# Altium schematic sheet Sensor-Sheet6.SchDoc(Sensor-Sheet6)
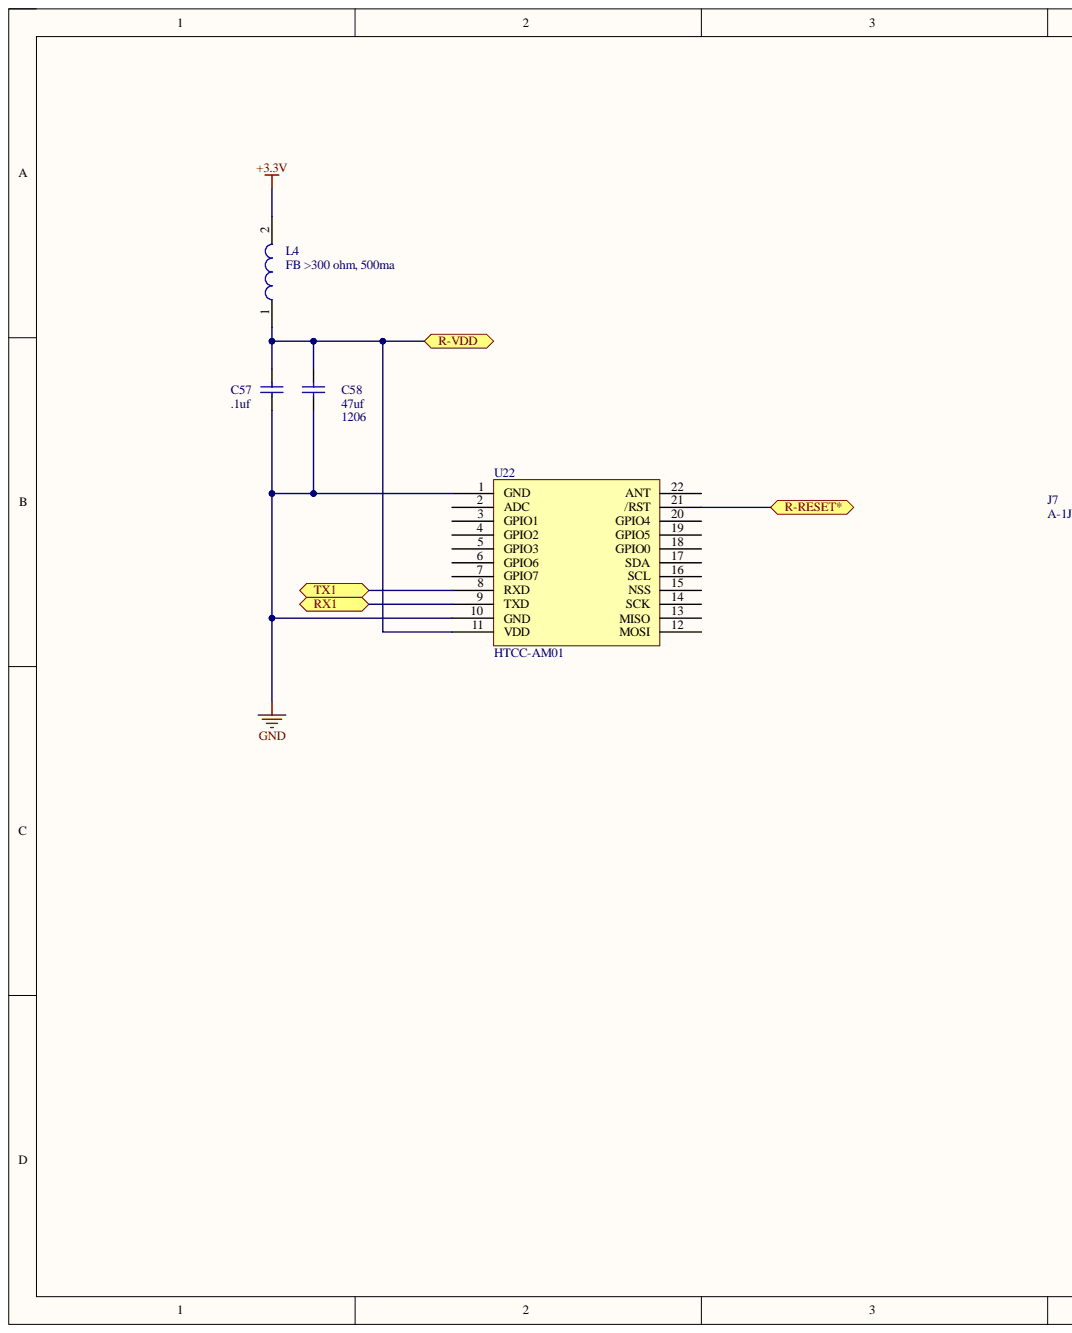
[diagram p0: Sensor-Sheet6.SchDoc, left]
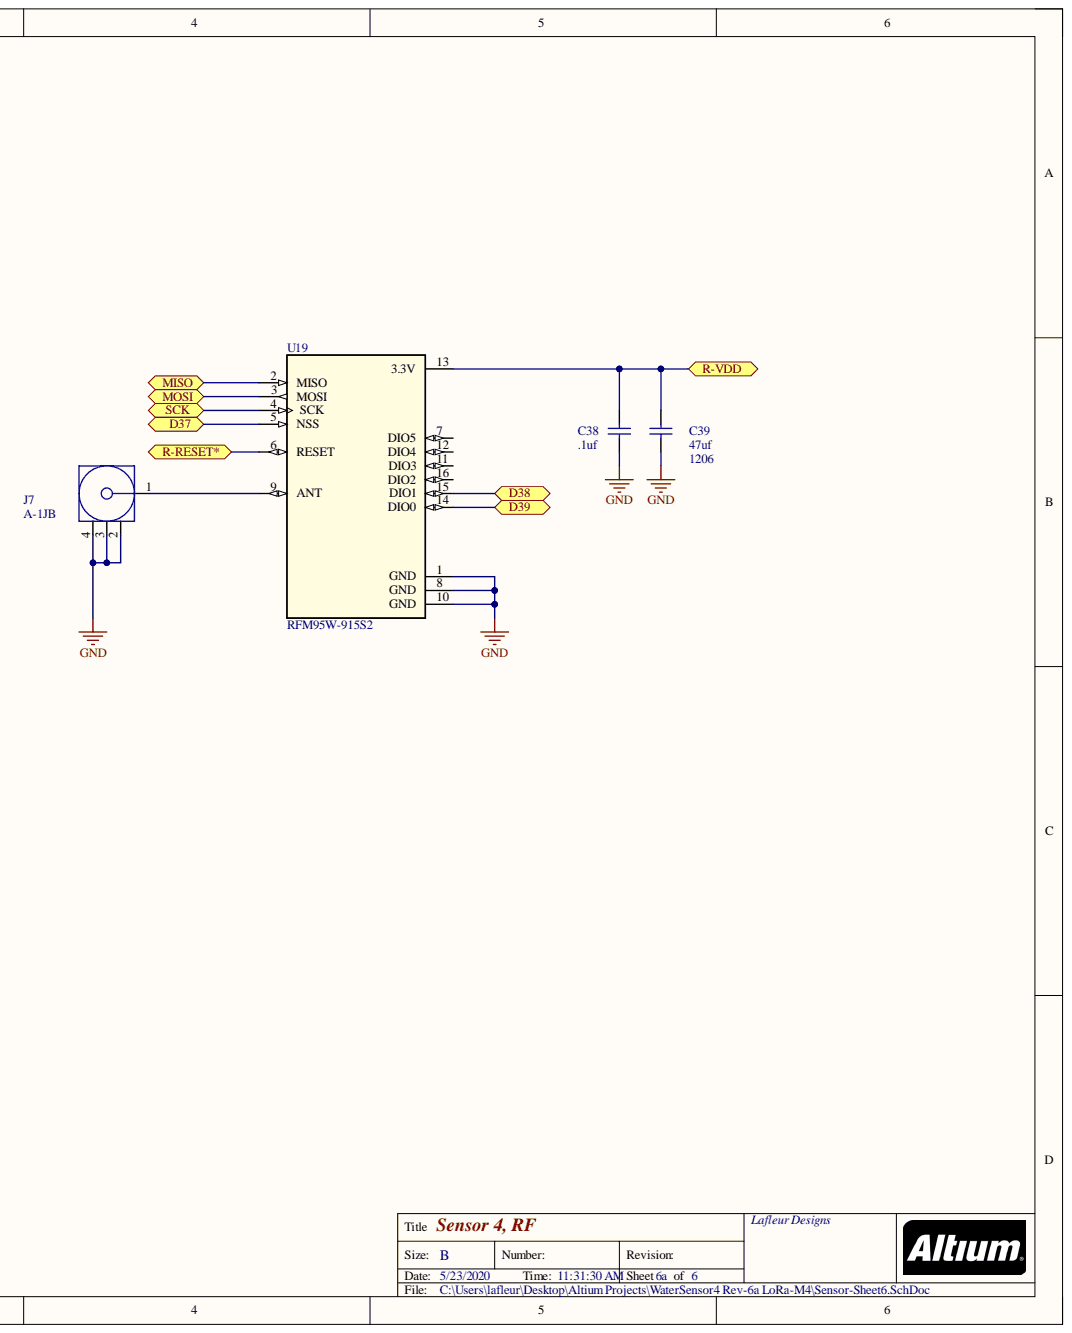
[diagram p1: Sensor-Sheet6.SchDoc, right]

## Parts
C38  .1uf  [c0805]
C39  47uf  [C1206]
C57  .1uf  [c0805]
C58  47uf  [C1206]
J7  A-1JB  [AMPH-A-1JB_V]
L4  FB >300 ohm, 500ma  [INDC2012X85N]
U19  RFM95W-915S2  [RFM95W]
U22  HTCC-AM01  [HTCC-AM01]

## Ports
D37, D38, D39, MISO, MOSI, R-RESET*, R-VDD, RX1, SCK, TX1

## Nets
+3.3V: L4.2
GND: C38.2 C39.2 C57.2 C58.2 J7.2 J7.3 J7.4 U19.1(GND) U19.8(GND) U19.10(GND) U22.1(GND) U22.10(GND)
D37: U19.5(NSS) ; port D37
D38: U19.15(DIO1) ; port D38
D39: U19.14(DIO0) ; port D39
MISO: U19.2(MISO) ; port MISO
MOSI: U19.3(MOSI) ; port MOSI
R-RESET*: U19.6(RESET) U22.21(/RST) ; port R-RESET*
R-VDD: C38.1 C39.1 C57.1 C58.1 L4.1 U19.13(3.3V) U22.11(VDD) ; port R-VDD
RX1: U22.9(TXD) ; port RX1
SCK: U19.4(SCK) ; port SCK
TX1: U22.8(RXD) ; port TX1
NetJ7_1: J7.1 U19.9(ANT)
NetU19_7: U19.7(DIO5)
NetU19_11: U19.11(DIO3)
NetU19_12: U19.12(DIO4)
NetU19_16: U19.16(DIO2)
NetU22_2: U22.2(ADC)
NetU22_3: U22.3(GPIO1)
NetU22_4: U22.4(GPIO2)
NetU22_5: U22.5(GPIO3)
NetU22_6: U22.6(GPIO6)
NetU22_7: U22.7(GPIO7)
NetU22_12: U22.12(MOSI)
NetU22_13: U22.13(MISO)
NetU22_14: U22.14(SCK)
NetU22_15: U22.15(NSS)
NetU22_16: U22.16(SCL)
NetU22_17: U22.17(SDA)
NetU22_18: U22.18(GPIO0)
NetU22_19: U22.19(GPIO5)
NetU22_20: U22.20(GPIO4)
NetU22_22: U22.22(ANT)

## Library data of the parts
C38 C39 C57 C58 = CAP_1: Capacitor; Fitted: True; Component Kind: Standard; Library Name: N2K Project.SCHLIB
J7 = CMP-1743-00001-1: AMC PCB Jack, 0 to 60 GHz, 60 V, -40 to 90 degC, 4-Pin SMD, RoHS, Tape and Reel; Capacitance: 100 uF; Case\Package: 2917; ESR: 700 mohm; Tolerance: 10%; Voltage Rating: 10 V; Case Code (Imperial): 2917; Case Code (Metric): 7343; Component Kind: Standard; ComponentLink1Description: Datasheet; ComponentLink1URL: http://drawings.amphenolrf.com/Customer_Outline/CA-1JB.pdf; DatasheetVersion: Rev. K, 05/2016; Height: 3.1 mm; Length: 7.3 mm; Library Name: Altium Content Vault; Max Operating Temperature: 125 degC; Min Operating Temperature: -55 degC; Mount: Surface Mount; Package Quantity: 1; PackageDescription: 4-Pin Surface Mount Device, Body 2.6 x 2.6 mm; PackageReference: A-1JB; Packaging: Tape and Reel; Pins: 2; Radiation Hardening: No; REACH SVHC: No SVHC; RoHSCompliant: true; Voltage Rating (DC): 10 V; Weight: 0.023634 oz; Width: 4.3 mm
L4 = MMZ2012Y300B: Inductor; Manufacturer_Name: TDK; Component Kind: Standard; Datasheet Link: https://product.tdk.com/info/en/catalog/datasheets/beads_commercial_signal_mmz2012_en.pdf; Height: 0.85mm; Manufacturer_Part_Number: MMZ2012Y300B; Mouser Part Number: 810-MMZ2012Y300B; Mouser Price/Stock: https://www.mouser.com/Search/Refine.aspx?Keyword=810-MMZ2012Y300B
U19 = RFM95W-915S2: General ISM < 1GHz LoRaâ„¢ Transceiver Module 915MHz Surface Mount; MF: RF Solutions; Component Kind: Standard; Digi-Key_Part_Number: RFM95W-915S2-ND; Digi-Key_Purchase_URL: https://www.digikey.com/product-detail/en/rf-solutions/RFM95W-915S2/RFM95W-915S2-ND/6564923?utm_source=snapeda&utm_medium=aggregator&utm_campaign=symbol; MP: RFM95W-915S2; Package: SMD-16 RF Solutions; SnapEDA_Link: https://www.snapeda.com/parts/RFM95W-915S2/RF%20Solutions/view-part/585488/?ref=snap
U22 = HTCC-AM01: Component Kind: Standard; Library Name: WaterSensor V4-6_10.SCHLIB
